# Altium schematic sheet Power.SchDoc
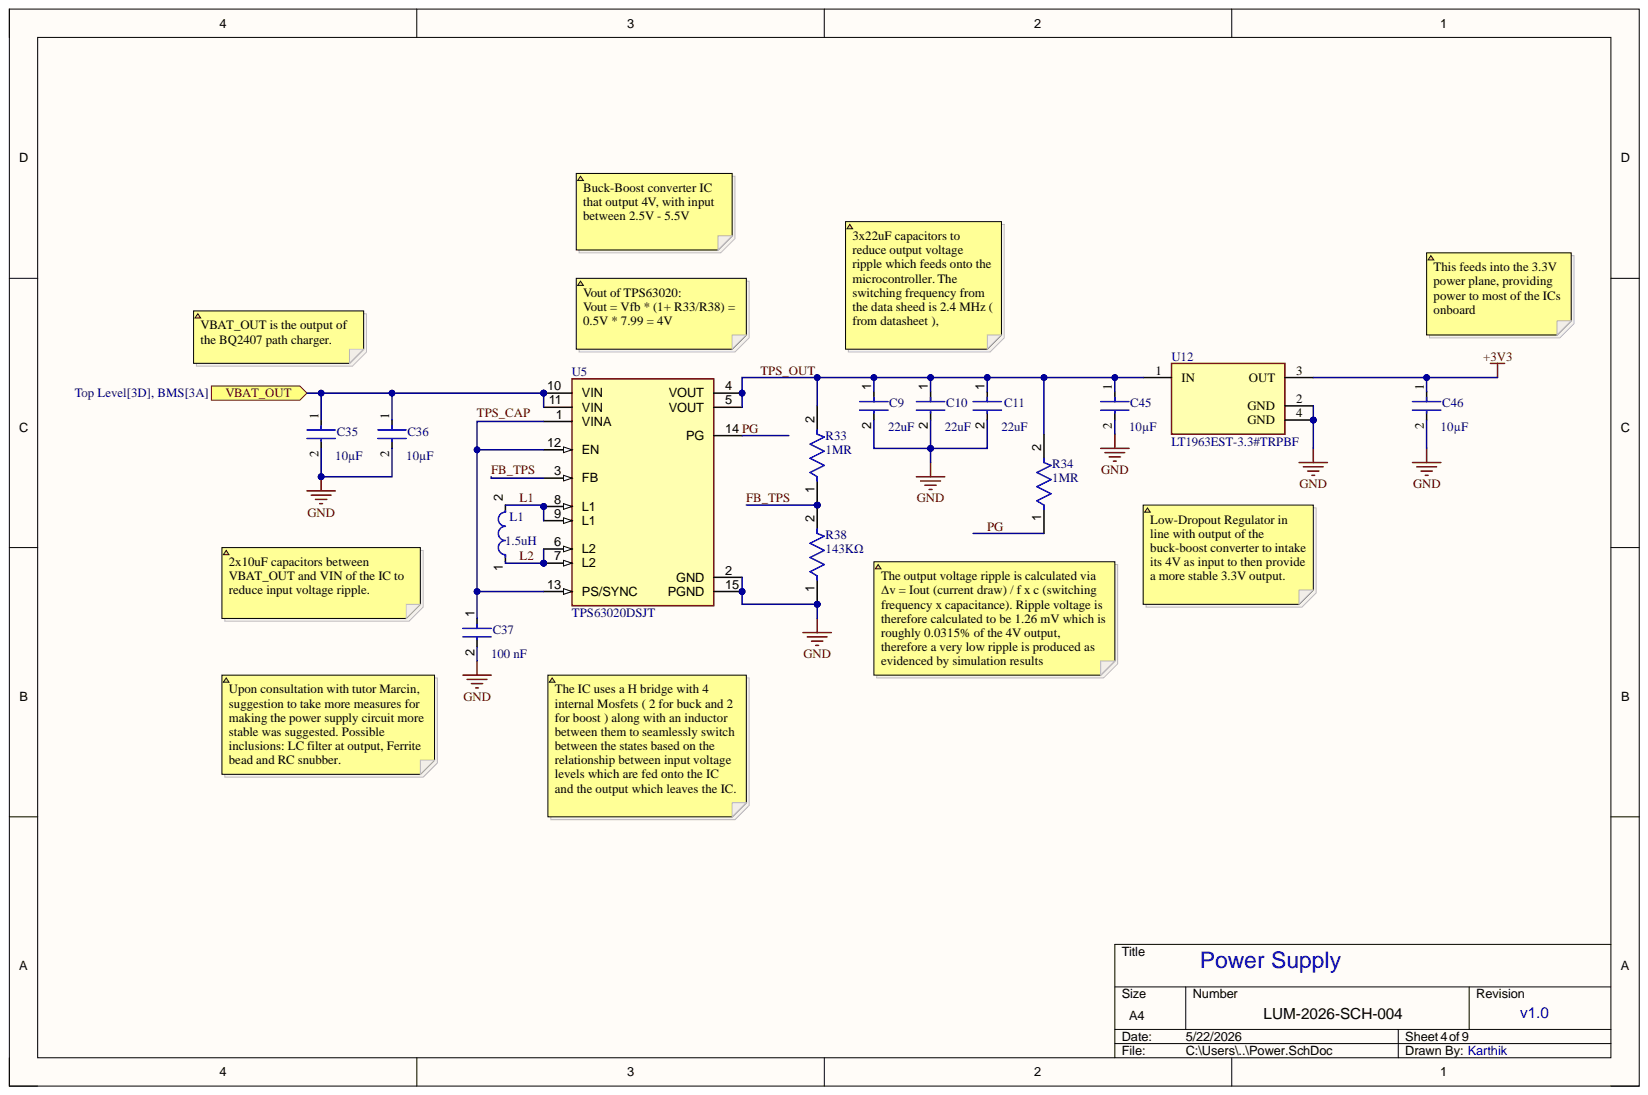
[diagram p0: Power.SchDoc]

## Parts
C9  Capacitance: 22uF  [FP-GRM188-0_2-IPC_B]  (hidden comment: GRM188C80J226ME15D)
C10  Capacitance: 22uF  [FP-GRM188-0_2-IPC_B]  (hidden comment: GRM188C80J226ME15D)
C11  Capacitance: 22uF  [FP-GRM188-0_2-IPC_B]  (hidden comment: GRM188C80J226ME15D)
C35  Capacitance: 10µF  [FP-CC0603-DC-MFG]  (hidden comment: CC0603KRX5R7BB106)
C36  Capacitance: 10µF  [FP-CC0603-DC-MFG]  (hidden comment: CC0603KRX5R7BB106)
C37  value 100 nF  Capacitance: 100 nF  [FP-CC0603-DA-MFG]  (hidden comment: CC0603KPX7R8BB104)
C45  Capacitance: 10µF  [FP-CC0603-DC-MFG]  (hidden comment: CC0603KRX5R7BB106)
C46  Capacitance: 10µF  [FP-CC0603-DC-MFG]  (hidden comment: CC0603KRX5R7BB106)
L1  Inductance: 1.5uH  [FP-NRS5020-MFG]  (hidden comment: NRS5020T1R5NMGJ)
R33  Resistance: 1MR  [FP-CRGP0603-IPC_C]  (hidden comment: CRGP0603F1M0)
R34  Resistance: 1MR  [FP-CRGP0603-IPC_C]  (hidden comment: CRGP0603F1M0)
R38  value 143KΩ  Resistance: 143KΩ  [FP-CPF0603-MFG]  (hidden comment: CPF0603F143KC1)
U5  TPS63020DSJT  [FP-DSJ0014A-MFG]
U12  LT1963EST-3.3#TRPBF  [FP-ST-3-05-08-1630-MFG]

## Ports
VBAT_OUT

## Nets
+3V3: C46.1 U12.3(OUT)
GND: C9.2 C10.2 C11.2 C35.2 C36.2 C37.2 C45.2 C46.2 R38.1 U5.2(GND) U5.15(PGND) U12.2(GND) U12.4(GND)
FB_TPS: R33.1 R38.2 U5.3(FB)
L1: L1.2 U5.8(L1) U5.9(L1)
L2: L1.1 U5.6(L2) U5.7(L2)
PG: R34.1 U5.14(PG)
TPS_OUT: C9.1 C10.1 C11.1 C45.1 R33.2 R34.2 U5.4(VOUT) U5.5(VOUT) U12.1(IN)
VBAT_OUT: C35.1 C36.1 U5.10(VIN) U5.11(VIN) ; port VBAT_OUT
NetC37_1: C37.1 U5.1(VINA) U5.12(EN) U5.13(PS/SYNC)
TPS_CAP:

## Library data of the parts
C9-C11 = CMP-06035-001854-1: Chip Multilayer Ceramic Capacitors for General Purpose, 0603, 22uF, X6S, 22%, 20%, 6.3V; Case Code (Imperial): 0603; Case Code (Metric): 1608; Case/Package: 0603; Component Kind: Standard; Dielectric: X6S; Height: 0.8mm; Lead Free: Lead Free; Length: 0.063inch; Library Name: Altium Content Vault; Max Operating Temperature: 105°C; Min Operating Temperature: -55°C; Mount: Surface Mount; Package Quantity: 4000; Packaging: Tape and Reel; Termination: SMD/SMT; Thickness: 0.039inch; Tolerance: 20%; Voltage Rating: 6.3V; Voltage Rating (DC): 6.3V; Weight: 0.000222oz; Width: 0.8mm
C35 C36 C45 C46 = CMP-00621-81932632-1: CC0603KRX5R7BB106 Multilayer Ceramic Capacitor, 10 uF, 16 V, ± 10%, X5R, 0603 [1608 Metric]; Voltage Rating: 16V; Case/Package: 0603; Component Kind: Standard; Dielectric: X5R; Halogen Free: Halogen Free; Height: 1mm; Lead Free: Lead Free; Library Name: Altium Content Vault; Material: Ceramic; Max Operating Temperature: 85°C; Min Operating Temperature: -55°C; NexarPartId: 81932632; RoHS: Non-Compliant; Tolerance: 10%; Voltage Rating (DC): 16V
C37 = CMP-03422-000081-1: CC0603 100 nF X7R 10.00% 25 V; Manufacturer: YAGEO; Material: Ceramic; Temperature Coefficient: 15%; Tolerance: 0.1; Voltage: 25 V; Automotive: No; Category: Cap; Component Kind: Standard; ComponentLink2Description: Datasheet; ComponentLink2URL: http://www.yageo.com/documents/recent/UPY-GPHC_X7R_6.3V-to-50V_18.pdf; Device Class L1: Passive Components; Device Class L2: Capacitors; Device Class L3: Ceramic Capacitors; Footprint Url: http://www.yageo.com/exep/pages/download/literatures/UPY-C_GEN_24.pdf; Height: 0.9mm; Lead Free: Yes; Library Name: Altium Content Vault; Manufacturer Part Number: CC0603KPX7R8BB104; Mouser Description: Multilayer Ceramic Capacitors MLCC - SMD/SMT 100nF 25V X7R 10%; Octopart: https://octopart.com/cc0603kpx7r8bb104-yageo-12885111; Package: CC0603-DA; RoHS: TRUE; Temperature: -55°C to +125°C; Temperature Characteristic: X7R; Temperature Range High: +125°C; Temperature Range Low: -55°C; Voltage Rating: 25 V
L1 = CMP-14484-000741-1: Shielded Wirewound Inductor 1.5uH 30% 3.54A 31.2mΩ SMD; Core Material: Ferrite; Current Rating: 3.2A; DC Resistance (DCR): 31.2mR; Max DC Current: 3.35A; Self Resonant Frequency: 68MHz; Test Frequency: 100kHz; Case/Package: Nonstandard; Component Kind: Standard; Depth: 5.1mm; Halogen Free: Halogen Free; Height: 2mm; Height - Seated (Max): 0.079inch; Inductance Tolerance: 30%; Lead Free: Lead Free; Lead Pitch: 3.3mm; Length: 4.9mm; Library Name: Altium Content Vault; Max Operating Temperature: 125°C; Min Operating Temperature: -25°C; Mount: Surface Mount; Number of Pins: 2; Package Quantity: 1; Packaging: Tape and Reel; Radiation Hardening: No; Resistor Type: Wirewound; RMS Current (Irms): 3.2A; RoHS Compliant: Yes; Saturation Current: 3.35A; Series: NRS; Shielding: Shielded; Termination: SMD/SMT; Tolerance: 30%; Width: 4.9mm
R33 R34 = CMP-03211-004384-3: RES 1M OHM 1% 1/4W 0603; Max Power Dissipation: 250mW; Temperature Coefficient: 100ppm/°C; Case Code (Imperial): 0603; Case Code (Metric): 1608; Case/Package: 0603; Component Kind: Standard; Library Name: Altium Content Vault; Max Operating Temperature: 155°C; Min Operating Temperature: -55°C; Number of Terminations: 2; Packaging: Cut Tape; Resistor Type: Thick Film; RoHS Compliant: Yes; Tolerance: 1%
R38 = CMP-03211-007455-1: RES SMD 143KΩ 1% 1/16W 0603; Manufacturer: TE Connectivity; Power Rating: 0.063W; Temperature Coefficient: 50ppm/°C; Voltage Rating: 50V; Automotive: No; Category: Res; Component Kind: Standard; ComponentLink2Description: Datasheet; ComponentLink2URL: https://www.te.com/commerce/DocumentDelivery/DDEController?Action=showdoc&DocId=Data+Sheet%7F1773200%7FM%7Fpdf%7FEnglish%7FENG_DS_1773200_M.pdf%7F3-1676481-2; Composition: Thin Film; Device Class L1: Passive Components; Device Class L2: Resistors; Device Class L3: Chip SMD Resistors; Height: 0.55mm; Ipc Land Pattern Name: RESC15580X45; Lead Free: Yes; Library Name: Altium Content Vault; Manufacturer Part Number: CPF0603F143KC1; Octopart: https://octopart.com/cpf0603f143kc1-te+connectivity-7514988; octopart_url: https://octopart.com/cpf0603f143kc1-te+connectivity-7514988; Package: CPF0603; packaging: 1k Reel; RoHS: TRUE; Series: CPF; Temperature: -55°C to +155°C; Temperature Range High: +155°C; Temperature Range Low: -55°C; Tolerance: 1%
U5 = CMP-2000-07282-2: IC REG BCK BST ADJ 3.5A 14VSON; Manufacturer: Texas Instruments; Max Junction Temp: +125°C; Max Supply Voltage: 5.5V; Min Supply Voltage: 1.8V; Nominal Supply Current: 25uA; Number Of Outputs: 1; Output Current: 3A; Output Type: AdjustableProgrammable; Output Voltage: 1.2-5.5V; Standoff Height: 0mm; Switching Frequency: 2400kHz; Switching Topology: Buck-Boost; Ambient Temperature Range High: +85°C; Ambient Temperature Range Low: -40°C; Automotive: No; Category: IC; Component Kind: Standard; ComponentLink2Description: Datasheet; ComponentLink2URL: https://www.ti.com/lit/ds/symlink/tps63020.pdf; Device Class L1: Integrated Circuits (ICs); Device Class L2: Power Management ICs; Device Class L3: Voltage Regulators - Switching; Digikey Description: IC REG BCK BST ADJ 3.5A 14VSON; Height: 1mm; Lead Free: Yes; Library Name: Altium Content Vault; Manufacturer Part Number: TPS63020DSJT; Mouser Description: Voltage Regulators - Switching Regulators 93% Eff Buck-Boost Converter; Octopart: https://octopart.com/tps63020dsjt-texas+instruments-12976119; Package: DSJ0014A; RoHS: TRUE; Temperature: -40°C to +85°C; Temperature Range High: +85°C; Temperature Range Low: -40°C
U12 = CMP-09138-000161-1: IC REG LINEAR 3.3V 1.5A SOT223-3; Dropout Voltage: 340mV; Min Current Limit: 1.6A; Min Input Voltage: 1.9V; Number of Outputs: 1; Polarity: Positive; Case/Package: SOT-223; Component Kind: Standard; Lead Free: Lead Free; Library Name: Altium Content Vault; Max Operating Temperature: 125°C; Min Operating Temperature: -40°C; Mount: Surface Mount; Number of Pins: 4; Number of Regulators: 1; Output Current: 1.5A; Output Voltage: 3.3V; Package Quantity: 2000; Packaging: Tape and Reel; RoHS Compliant: Yes
